# Altium schematic sheet EXT_Interface.SchDoc
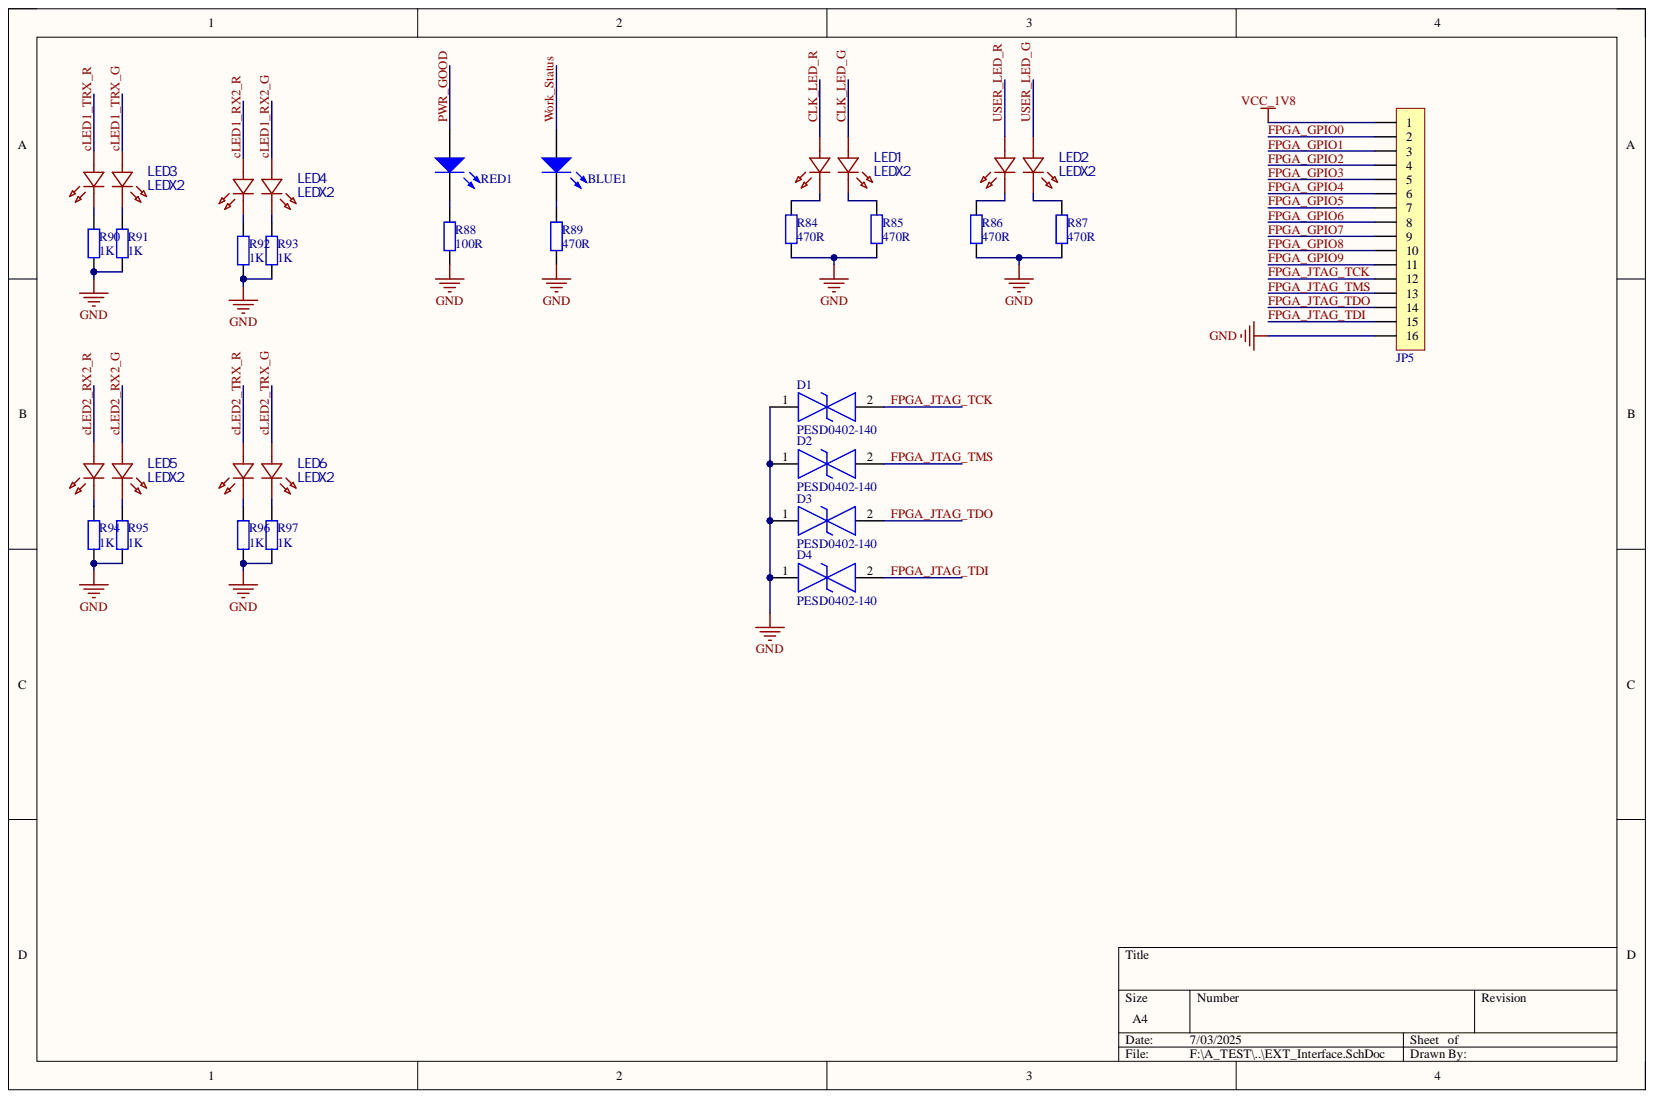
[diagram p0: EXT_Interface.SchDoc]

## Parts
BLUE1  LED  [LED 0402 B]  (value not drawn)
D1  PESD0402-140  [DIONC1005X43N]
D2  PESD0402-140  [DIONC1005X43N]
D3  PESD0402-140  [DIONC1005X43N]
D4  PESD0402-140  [DIONC1005X43N]
JP5  HDR-1X16  [FPC1.0-2H-WS-16P]  (value not drawn)
LED1  LEDX2  [LED-SMD_4P-L1.6-W1.5_F.1615.00003-P4-1615R1G1TA2-06T-001]
LED2  LEDX2  [LED-SMD_4P-L1.6-W1.5_F.1615.00003-P4-1615R1G1TA2-06T-001]
LED3  LEDX2  [LED-SMD_4P-L1.6-W1.5_F.1615.00003-P4-1615R1G1TA2-06T-001]
LED4  LEDX2  [LED-SMD_4P-L1.6-W1.5_F.1615.00003-P4-1615R1G1TA2-06T-001]
LED5  LEDX2  [LED-SMD_4P-L1.6-W1.5_F.1615.00003-P4-1615R1G1TA2-06T-001]
LED6  LEDX2  [LED-SMD_4P-L1.6-W1.5_F.1615.00003-P4-1615R1G1TA2-06T-001]
R84  470R  [R 0402_L]
R85  470R  [R 0402_L]
R86  470R  [R 0402_L]
R87  470R  [R 0402_L]
R88  100R  [R 0402_L]
R89  470R  [R 0402_L]
R90  1K  [R 0402_L]
R91  1K  [R 0402_L]
R92  1K  [R 0402_L]
R93  1K  [R 0402_L]
R94  1K  [R 0402_L]
R95  1K  [R 0402_L]
R96  1K  [R 0402_L]
R97  1K  [R 0402_L]
RED1  LED  [LED 0402 R]  (value not drawn)

## Nets
GND: D1.1 D2.1 D3.1 D4.1 JP5.16 R84.1 R85.1 R86.1 R87.1 R88.1 R89.1 R90.1 R91.1 R92.1 R93.1 R94.1 R95.1 R96.1 R97.1
VCC_1V8: JP5.1
cLED1_RX2_G: LED4.4
cLED1_RX2_R: LED4.3
cLED1_TRX_G: LED3.4
cLED1_TRX_R: LED3.3
cLED2_RX2_G: LED5.4
cLED2_RX2_R: LED5.3
cLED2_TRX_G: LED6.4
cLED2_TRX_R: LED6.3
CLK_LED_G: LED1.4
CLK_LED_R: LED1.3
FPGA_GPIO0: JP5.2
FPGA_GPIO1: JP5.3
FPGA_GPIO2: JP5.4
FPGA_GPIO3: JP5.5
FPGA_GPIO4: JP5.6
FPGA_GPIO5: JP5.7
FPGA_GPIO6: JP5.8
FPGA_GPIO7: JP5.9
FPGA_GPIO8: JP5.10
FPGA_GPIO9: JP5.11
FPGA_JTAG_TCK: D1.2 JP5.12
FPGA_JTAG_TDI: D4.2 JP5.15
FPGA_JTAG_TDO: D3.2 JP5.14
FPGA_JTAG_TMS: D2.2 JP5.13
PWR_GOOD: RED1.1
USER_LED_G: LED2.4
USER_LED_R: LED2.3
Work_Status: BLUE1.1
NetBLUE1_2: BLUE1.2 R89.2
NetLED1_1: LED1.1 R85.2
NetLED1_2: LED1.2 R84.2
NetLED2_1: LED2.1 R87.2
NetLED2_2: LED2.2 R86.2
NetLED3_1: LED3.1 R91.2
NetLED3_2: LED3.2 R90.2
NetLED4_1: LED4.1 R93.2
NetLED4_2: LED4.2 R92.2
NetLED5_1: LED5.1 R95.2
NetLED5_2: LED5.2 R94.2
NetLED6_1: LED6.1 R97.2
NetLED6_2: LED6.2 R96.2
NetR88_2: R88.2 RED1.2

## Library data of the parts
BLUE1 RED1 = LED: 通用; Component Kind: Standard; Library Name: A_Schlib.SchLib
D1-D4 = PESD0402-140: TVS Diode (Bi-directional); Manufacturer_Name: LITTELFUSE; Arrow Part Number: PESD0402-140; Arrow Price/Stock: https://www.arrow.com/en/products/pesd0402-140/littelfuse?utm_currency=USD&region=nac; Component Kind: Standard; Datasheet Link: https://www.littelfuse.com/~/media/electronics/product_specifications/polymer_esd_suppressors/littelfuse_polymer_esd_suppressor_pesd0402_140_product_specification.pdf.pdf; Height: 0.43mm; Library Name: SamacSys.SchLib; Manufacturer_Part_Number: PESD0402-140; Mouser Part Number: 650-PESD0402-140; Mouser Price/Stock: https://www.mouser.co.uk/ProductDetail/Littelfuse/PESD0402-140?qs=y1EbU1W0OrtTHVfbQV6MTg%3D%3D
JP5 = Header 16: 16P接插件; 版权归属: 封装库来自淘宝卖家 ID：黄晶宝石; BOM名称: 16P接插件; Component Kind: Standard; 学习资源: https://shop463601444.taobao.com; Library Name: 2.54间距排针排母插件贴片.SchLib
LED1-LED6 = LEDX2: Manufacturer: Amicc(欧密格光电); Add into BOM: yes; Device: A-SP1942R6GHC-A01-2T; Illumination Color: 红色,翠绿色; Lens Color: 无色透明透镜; Luminous Intensity: 28.5mcd~72mcd；180mcd~450mcd; Mounting Sytle: 正贴; Power(Watts): 95mW；60mW; Viewing Angle: 130°; Wavelength - Dominant: 520nm~535nm；616nm~626nm; Component Kind: Standard; Convert to PCB: yes; Datasheet: https://atta.szlcsc.com/upload/public/pdf/source/20231108/6CC0465F260EB0273BA2FCC598291934.pdf; JLCPCB Part Class: 扩展库; LCSC Part Name: 1615红绿双色正贴; Library Name: A_Schlib.SchLib; Manufacturer Part: A-SP1942R6GHC-A01-2T; Operating Temperature: -40℃~+80℃; PCB3D: LED-SMD_4P-L1.6-W1.5_F.1615.00003-P4-1615R1G1TA2-06T-001; Supplier: LCSC; Supplier Footprint: SMD-4P,1.6x1.5mm; Supplier Part: C19171224; Symbol: A-SP1942R6GHC-A01-2T
R84-R97 = Res: 通用; Component Kind: Standard; Library Name: A_Schlib.SchLib
  R84-R87 R89: value: 470R
  R88: value: 100R
  R90-R97: value: 1K
